# Altium schematic sheet Sheet1.SchDoc
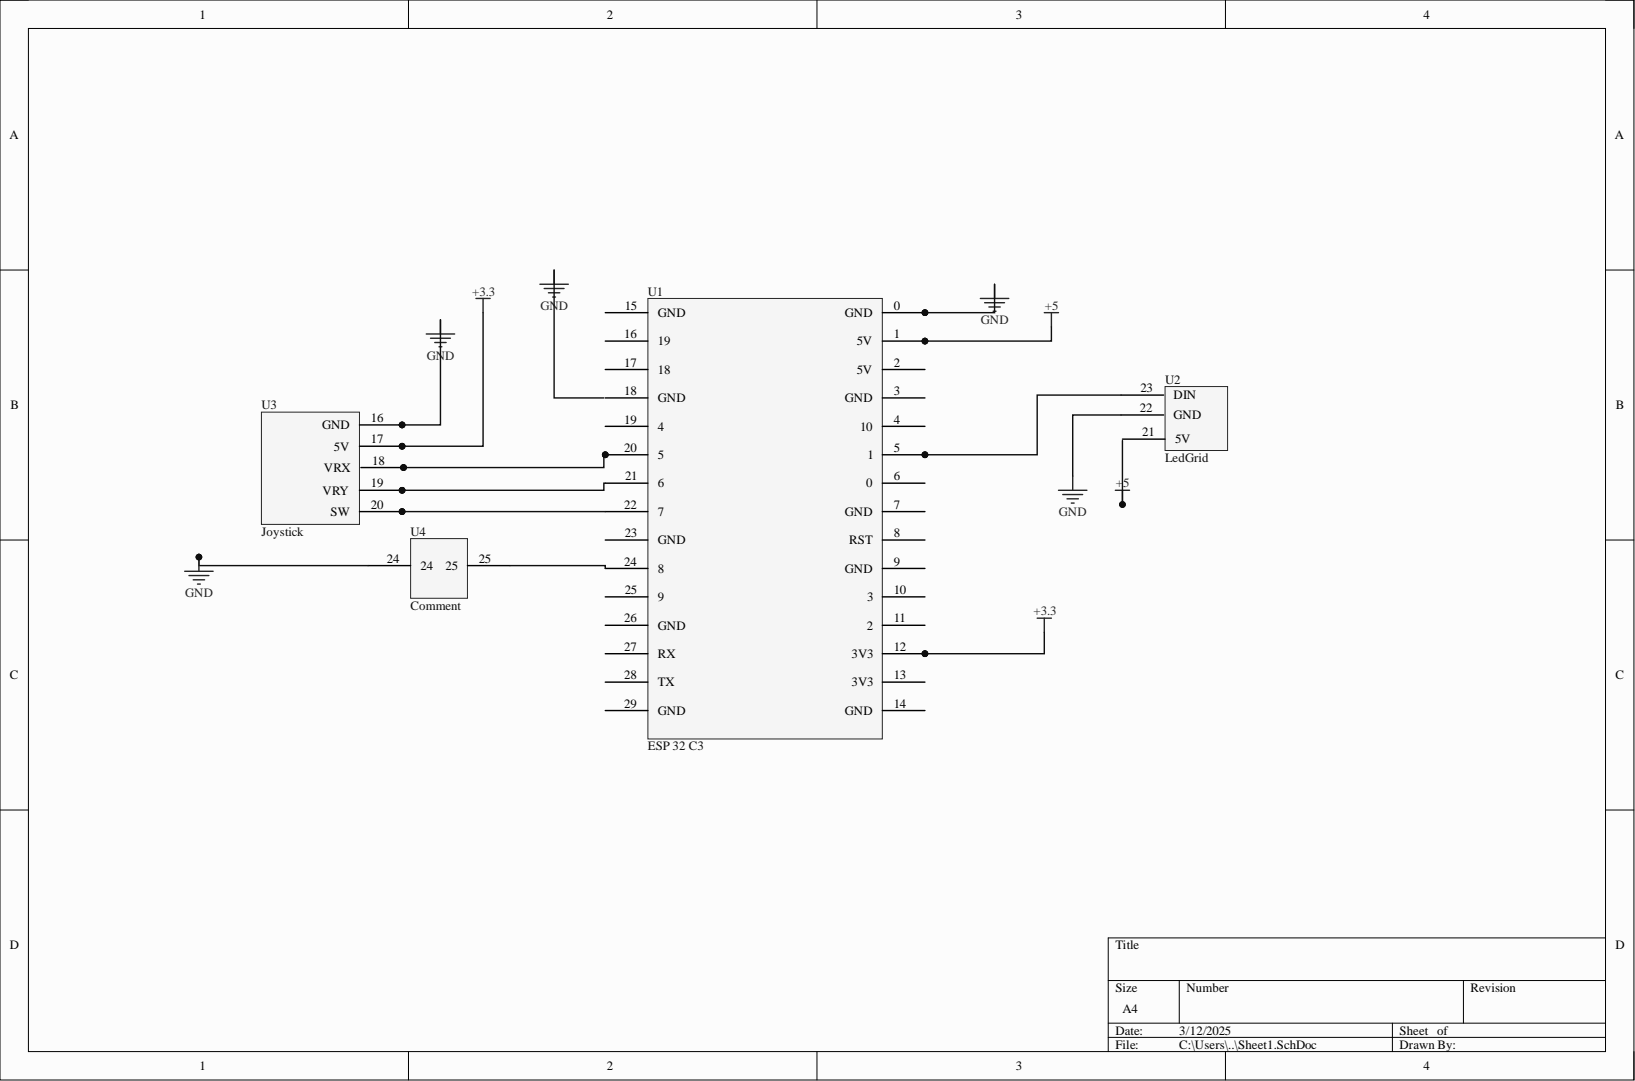
[diagram p0: Sheet1.SchDoc]

## Parts
U1  ESP 32 C3
U2  LedGrid  [LedGrid]
U3  Joystick
U4  Comment  [Buzzer]

## Nets
+3.3: U1.12(3V3) U3.17(5V)
+5: U1.1(5V)
GND: U1.0(GND) U2.22 U3.16(GND) U4.24
NetU1_5: U1.5 U2.23
NetU1_20: U1.20 U3.18(VRX)
NetU1_21: U1.21 U3.19(VRY)
NetU1_22: U1.22 U3.20(SW)
NetU1_24: U1.24 U4.25

Not connected: U1 2(5V) 3(GND) 4 6 7(GND) 8(RST) 9(GND) 10 11 13(3V3) 14(GND) 15(GND) 16 17 18(GND) 19 23(GND) 25 26(GND) 27(RX) 28(TX) 29(GND); U2 21

## Library data of the parts
U4 = Buzzer: Component Kind: Standard; Library Name: Schlib1.SchLib
U1 = ESP 32 C3: Component Kind: Standard; Library Name: Schlib1.SchLib
U2 = LedGrid: Component Kind: Standard; Library Name: Schlib1.SchLib
U3 = Joystick: Component Kind: Standard; Library Name: Schlib1.SchLib
